# Altium schematic sheet Mako DSP USB R2.0.SchDoc("Mako DSP USB R2.0")
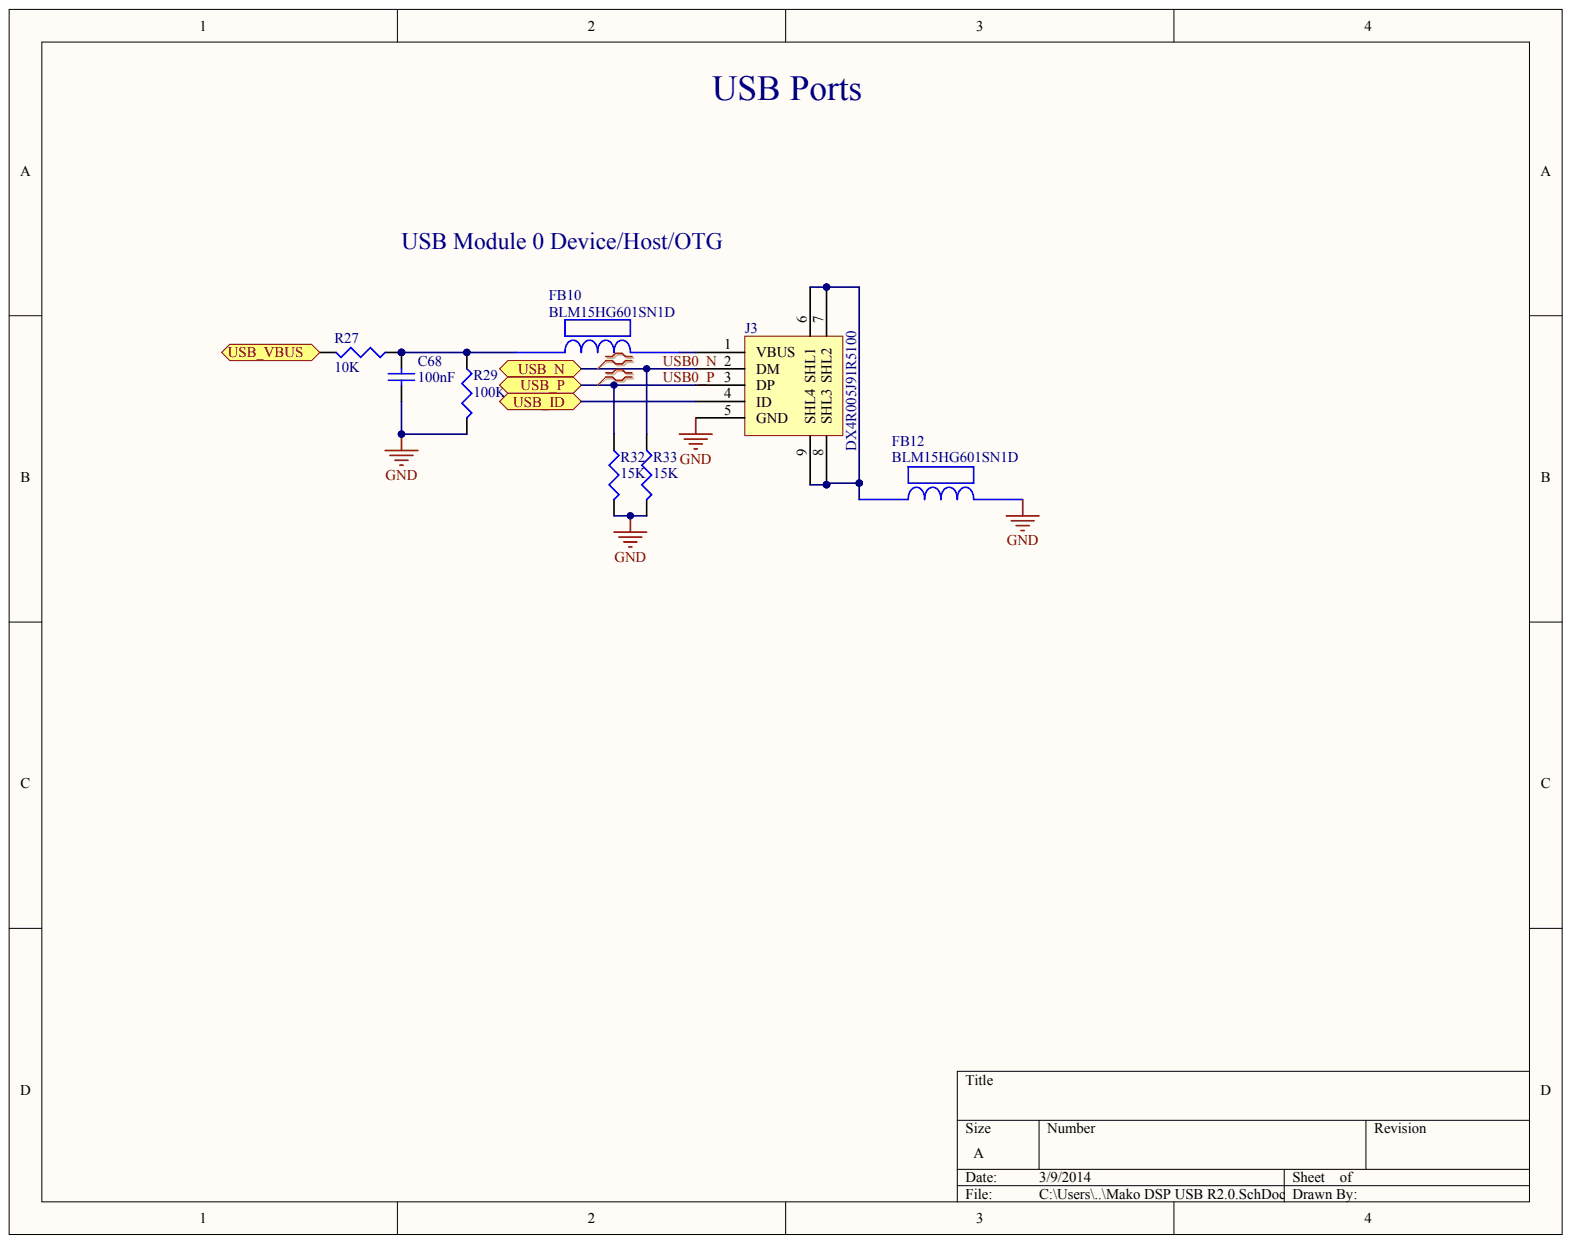
[diagram p0: Mako DSP USB R2.0.SchDoc]

## Parts
C68  value 100nF  (hidden comment: Cap Semi)
FB10  BLM15HG601SN1D
FB12  BLM15HG601SN1D
J3  DX4R005J91R5100
R27  value 10K  (hidden comment: Res3)
R29  value 100K  (hidden comment: Res3)
R32  value 15K  (hidden comment: Res3)
R33  value 15K  (hidden comment: Res3)

## Ports
USB_ID, USB_N, USB_P, USB_VBUS

## Nets
GND: C68.1 FB12.2 J3.5(GND) R29.2 R32.2 R33.2
USB0_N: J3.2(DM) R33.1 ; port USB_N
USB0_P: J3.3(DP) R32.1 ; port USB_P
USB_ID: J3.4(ID) ; port USB_ID
USB_VBUS: R27.2 ; port USB_VBUS
NetC68_2: C68.2 FB10.1 R27.1 R29.1
NetFB10_2: FB10.2 J3.1(VBUS)
NetFB12_1: FB12.1 J3.6(SHL1) J3.7(SHL2) J3.8(SHL3) J3.9(SHL4)

## Library data of the parts
C68 FB10 FB12 J3 R27 R29 R32 R33 = (no symbol name)
  C68: Published: 8-Jun-2000; LatestRevisionDate: 29-May-2009; LatestRevisionNote: IPC-7351 Footprint Added.; PackageReference: C1206; PackageDescription: Chip Capacitor
  R27 R29 R32 R33: Published: 8-Jun-2000; LatestRevisionDate: 29-May-2009; LatestRevisionNote: IPC-7351 Footprint Added.; PackageReference: J1-0603; PackageDescription: Chip Resistor
  C68 R27 R29 R32 R33: Publisher: Altium Limited
